# Altium schematic sheet Sheet1.SchDoc(Sheet1)
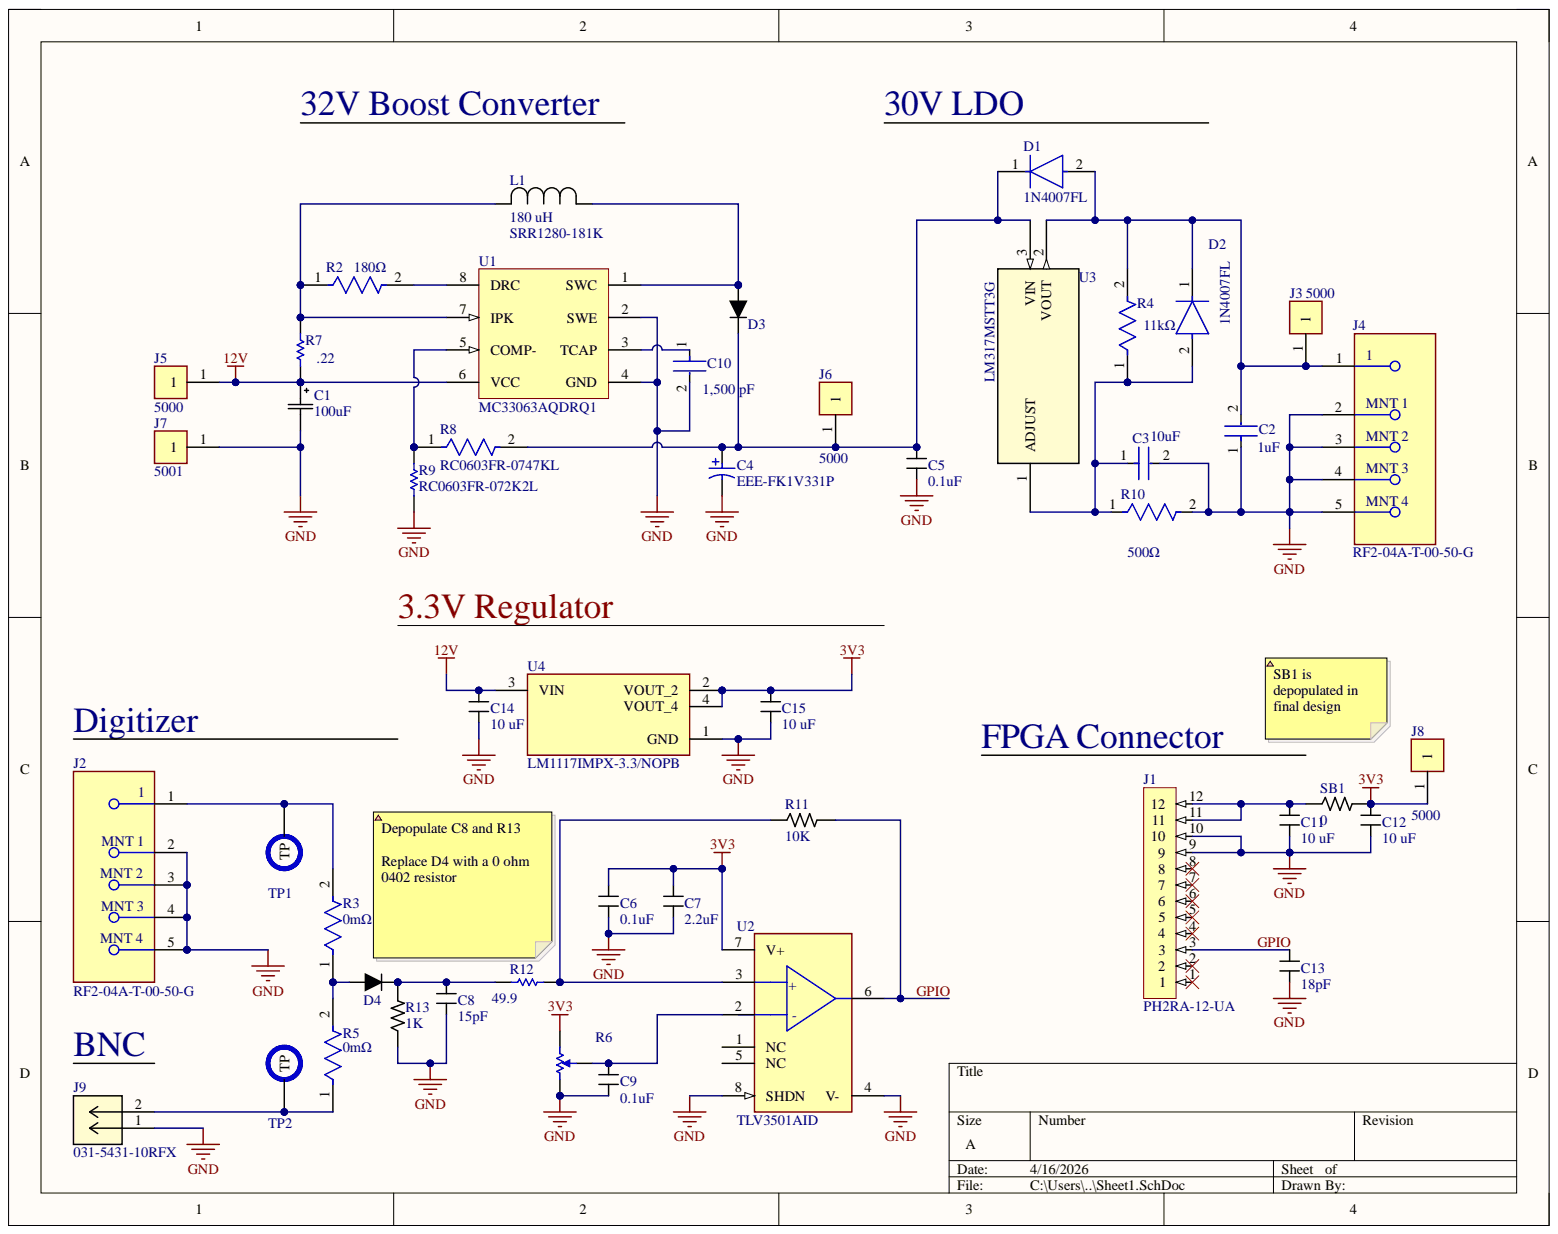
[diagram p0: Sheet1.SchDoc]

## Parts
C1  value 100uF  [PCAP_8x5.4-ELECT_NCA]  (hidden comment: 100uF electrolytic 35 V)
C2  Capacitance: 1uF  [FP-0603-L_1_6_0_1-W_0_8_0-IPC_A]  (hidden comment: UMK107BJ105KA-T)
C3  Capacitance: 10uF  [FP-GRM188-0_2-IPC_C]  (hidden comment: GRM188R6YA106MA73D)
C4  EEE-FK1V331P  [PNSC-EEE-FK1V331P_L]
C5  value 0.1uF  [CAPACITOR_0805]  (hidden comment: 100nF Ceramic 50V)
C6  value 0.1uF  [CAPACITOR_0603]  (hidden comment: 100nF Ceramic 25V)
C7  value 2.2uF  [CAPACITOR_0402]  (hidden comment: 2.2uF Ceramic 35V)
C8  value 15pF  [CAPACITOR_0402]  (hidden comment: 15pF Ceramic 50V)
C9  value 0.1uF  [CAPACITOR_0603]  (hidden comment: 100nF Ceramic 25V)
C10  value 1,500 pF  [FP-C0603C-CF-MFG]  (hidden comment: C0603C152F1GACTU)
C11  Value (uF): 10 uF  [CAPACITOR_0603]  (hidden comment: 10uF Ceramic 6.3V)
C12  Value (uF): 10 uF  [CAPACITOR_0603]  (hidden comment: 10uF Ceramic 6.3V)
C13  value 18pF  [CAPACITOR_0603]  (hidden comment: 18pF Ceramic 50V)
C14  Value (uF): 10 uF  [CAPACITOR_0603]  (hidden comment: 10uF Ceramic 6.3V)
C15  Value (uF): 10 uF  [CAPACITOR_0603]  (hidden comment: 10uF Ceramic 6.3V)
D1  1N4007FL  [FP-1N4007FL-MFG]
D2  1N4007FL  [FP-1N4007FL-MFG]
D3  STPS140Z  [SOD-123]  (value not drawn)
D4  BAR9002ELE6327XTMA1  [DIODE_0402]  (value not drawn)
J1  PH2RA-12-UA  [PH2RA-12-UA-Footprint-1]
J2  RF2-04A-T-00-50-G  [FP-RF2-04A-T-00-50-G-MFG]
J3  5000  [FP-5000-MFG]
J4  RF2-04A-T-00-50-G  [FP-RF2-04A-T-00-50-G-MFG]
J5  5000  [FP-5000-MFG]
J6  5000  [FP-5000-MFG]
J7  5001  [FP-5001-MFG]
J8  5000  [FP-5000-MFG]
J9  031-5431-10RFX  [031-5431-10RFX-Footprint-1]
L1  SRR1280-181K  value 180 uH  [SRR1280]
R2  value 180Ω  Resistance: 180Ω  [FP-CRGCQ0603-IPC_C]  (hidden comment: CRGCQ0603F180R)
R3  value 0mΩ  Resistance: 0mΩ  [FP-RMCF0603-MFG]  (hidden comment: RMCF0603ZT0R00)
R4  ERA-2AEB113X  [FP-ERA2A-MFG]  (value not drawn)
R5  value 0mΩ  Resistance: 0mΩ  [FP-RMCF0603-MFG]  (hidden comment: RMCF0603ZT0R00)
R6  TC33X-2-103E  [BOUR-TC33X-2_V]  (value not drawn)
R7  value .22  [RESC1608X55X30NL15T15]  (hidden comment: ERJ-3RQFR22V)
R8  RC0603FR-0747KL  [FP-RC0603FR-0747KL-MFG]
R9  RC0603FR-072K2L  [RESC1608X55X25LL10T15]
R10  Resistance: 500Ω  [RESC16080X45_RT0603-0_55_MFG]  (hidden comment: RT0603BRC07500RL)
R11  Value  (Ohms): 10K  [RESISTOR_0402]  (hidden comment: 10k Ohm 1% 1/16W)
R12  value 49.9  [RESC1005X40X25LL05T10]  (hidden comment: RC0402FR-0749R9L)
R13  Value  (Ohms): 1K  [RESISTOR_0603]  (hidden comment: 1k Ohm 5% 1/8W)
SB1  value 0  [RESISTOR_1206]  (hidden comment: JUMPER_0_1206)
TP1  PCB Test Point  [TESTPOINT_1.2MM]  (value not drawn)  (no BOM)
TP2  PCB Test Point  [TESTPOINT_1.2MM]  (value not drawn)  (no BOM)
U1  MC33063AQDRQ1  [D0008A_L]
U2  TLV3501AID  [FP-D0008A-IPC_C]
U3  LM317MSTT3G  [SOT223-3]
U4  LM1117IMPX-3.3/NOPB  [SOT-223-4]

## Nets
3V3: C6.1 C7.1 C12.1 C15.1 J8.1 R6.3 SB1.1 U2.7(V+) U4.2(VOUT_2) U4.4(VOUT_4)
12V: C1.1 C14.1 J5.1 R7.1 U1.6(VCC) U4.3(VIN)
GND: C1.2 C2.1 C3.2 C4.2 C5.2 C6.2 C7.2 C8.2 C9.2 C10.2 C11.2 C12.2 C13.2 C14.2 C15.2 J1.9 J1.10 J2.2 J2.3 J2.4 J2.5 J4.2 J4.3 J4.4 J4.5 J7.1 J9.1 R6.1 R9.1 R10.2 R13.2 U1.2(SWE) U1.4(GND) U2.4(V-) U2.8(SHDN) U4.1(GND)
GPIO: C13.1 J1.3 R11.1 U2.6
NetC2_2: C2.2 D1.2 D2.1 J3.1 J4.1 R4.2 U3.2
NetC3_1: C3.1 D2.2 R4.1 R10.1 U3.1
NetC4_1: C4.1 C5.1 D1.1 D3.2 J6.1 R8.2 U3.3
NetC8_1: C8.1 D4.2 R12.1 R13.1
NetC9_1: C9.1 R6.2 U2.2
NetC10_1: C10.1 U1.3(TCAP)
NetC11_1: C11.1 J1.11 J1.12 SB1.2
NetD3_1: D3.1 L1.1 U1.1(SWC)
NetD4_1: D4.1 R3.1 R5.2
NetJ2_1: J2.1 R3.2 TP1.TP
NetJ9_2: J9.2 R5.1 TP2.TP
NetL1_2: L1.2 R2.1 R7.2 U1.7(IPK)
NetR2_2: R2.2 U1.8(DRC)
NetR8_1: R8.1 R9.2 U1.5(COMP-)
NetR11_2: R11.2 R12.2 U2.3

Not connected: J1 1 2 4 5 6 7 8; U2 1(NC) 5(NC)

## Library data of the parts
C1 = CAP ALUM 100UF 20% 35V SMD: 100 µF 35 V Aluminum Electrolytic Capacitors Radial, Can - SMD 1000 Hrs; Manufacturer_Part_Number: UCB1V0R1MCL1GS; Mfr_Name: Nichicon; Made by: Tyson Nguyen; Type: DEV; Component Kind: Standard; Library Name: Capacitors.SchLib; RefDes: RefDes; Supplier 1: Mouser; Supplier Part Number 1: 667-EEE-FT1V101AP; Copyright: Copyright (C) 2022 Ultra Librarian. All rights reserved.
C2 = CMP-2000-05447-3: None; Dielectric: X5R; Tolerance: 10%; Voltage Rating: 50V; Case Code (Imperial): 0603; Case Code (Metric): 1608; Case/Package: 0603; Component Kind: Standard; Construction: Flat; Contact Plating: Nickel; Depth: 0.8mm; Halogen Free: Halogen Free; Height: 0.8mm; Length: 1.6mm; Library Name: Altium Content Vault; Max Operating Temperature: 85°C; Min Operating Temperature: -55°C; Mount: Surface Mount; Number of Pins: 2; Package Quantity: 1; Packaging: Tape and Reel; Radiation Hardening: No; RoHS Compliant: Yes; Series: M; Termination: SMD/SMT; Thickness: 0.035inch; Voltage Rating (DC): 50V; Width: 0.031inch
C3 = CMP-06035-045466-1: Chip Multilayer Ceramic Capacitors for General Purpose, 0603, 10uF, X5R, 15%, 20%, 35V; Case Code (Imperial): 0603; Case Code (Metric): 1608; Case/Package: 0603; Component Kind: Standard; Dielectric: X5R; Length: 0.063inch; Library Name: Altium Content Vault; Max Operating Temperature: 85°C; Min Operating Temperature: -55°C; Mount: Surface Mount; Packaging: Tape and Reel; Thickness: 0.039inch; Tolerance: 20%; Voltage Rating: 35V; Voltage Rating (DC): 35V; Width: 0.031inch
C4 = CMP-2000-06212-1: Aluminum Electrolytic Capacitor, 330 uF, 35 V, -55 to 105 degC, 2-Pin CAPAE, RoHS, Tape and Reel; Manufacturer: Panasonic; Capacitance: 330µF; Impedance: 0.08O; Lifetime@Temperature: 2000Hrs@105°C; Rated Voltage: 35V; Ripple Current: 850mA; Type: Can; Case Size/Dimension: Ø10x10.2mm; Component Kind: Standard; Datasheet URL: https://datasheet.ciiva.com/29313/443481-da-01-en-smd-elko-vfk-g-330-uf-35v-29313592.pdf; Datasheet Version: 07/2008; Library Name: Altium Content Vault; Manufacturer URL: http://www.panasonic.com/; Mounting Technology: SurfaceMount; Operating Temp Range: -55to+105°C; Operating Temp-Max: 105°C; Package Description: 2-Pin Capacitor Aluminum Electrolytic, Body 10.3 x 10.3 mm, Height 10.5 mm; Package Reference: EEE-FK1V331P; Series: FK
C5 = CAPACITOR_0.1uF_0805: Cap 100nF 50V +/-10%  Ceramic 0805; Made By: Robert Pafford; Component Kind: Standard; Library Name: Capacitors.SchLib; Supplier 1: Newark; Supplier Part Number 1: 38AH3645; Tolerance: +/- 10%; Voltage: 50V
C6 C9 = CAPACITOR_0.1uF_0603_25V: Cap 100nF 25V +/-10%  Ceramic 0603; Made By: Flip B; Voltage: 6.3V; Component Kind: Standard; ComponentLink1Description: Datsheet; ComponentLink1URL: https://www.mouser.com/datasheet/2/212/KEM_C1002_X7R_SMD-1102033.pdf; Library Name: Capacitors.SchLib; Supplier 1: Digikey; Supplier Part Number 1: 1276-1006-1-ND; Tolerance: +/- 10%
C7 = CAPACITOR_2.2uF_0402: Cap 2.2uF 35V +/-10%  Ceramic 0402; Made By: Flip B; Component Kind: Standard; Library Name: Capacitors.SchLib; Supplier 1: Digi-Key; Supplier Part Number 1: 445-9028-1-ND; Tolerance: +/- 10%; Voltage: 35V
C8 = CAPACITOR_0.000015uF_0402: CAP CER 15PF 50V C0G/NP0 0402; Made By: Robert Pafford; Tolerance: +/- 5%; Component Kind: Standard; Library Name: Capacitors.SchLib; Supplier 1: Newark; Supplier Part Number 1: 82AC9245; Voltage: 50V
C10 = CMP-1035-01965-2: CAP CER 1500PF 100V NP0 0603; Manufacturer: KEMET; Automotive Grade: Grade 1; Material: Ceramic; Temperature Characteristic: C0G; Temperature Coefficient: 30ppm/°C; Tolerance: 0.01; Automotive: Yes; Capacitance: 1,500 pF; Category: Cap; Component Kind: Standard; ComponentLink2Description: Datasheet; ComponentLink2URL: https://api.kemet.com/component-edge/download/datasheet/C0603C100J5GACAUTO.pdf; Device Class L1: Passive Components; Device Class L2: Capacitors; Device Class L3: Ceramic Capacitors; Digikey Description: CAP CER 1500PF 100V NP0 0603; Height: 0.87mm; Lead Free: Yes; Library Name: Altium Content Vault; Manufacturer Part Number: C0603C152F1GACTU; Mouser Description: Multilayer Ceramic Capacitors MLCC - SMD/SMT 100volts 1500pF 1% C0G; Octopart: https://octopart.com/c0603c152f1gactu-kemet-19049933; Package: C0603C-CF; RoHS: TRUE; Temperature: -55°C to +125°C; Temperature Range High: +125°C; Temperature Range Low: -55°C; Voltage Rating: 100 V
C11 C12 C14 C15 = CAPACITOR_10uF_0603: Cap 10uF 6.3V +/-20%  Ceramic 0603; Made By: Flip B; Component Kind: Standard; ComponentLink1Description: Digikey; ComponentLink1URL: https://www.digikey.com/en/products/detail/samsung-electro-mechanics/CL10A106MQ8NNNC/3886777; Library Name: Capacitors.SchLib; Supplier 1: Digi-Key; Supplier Part Number 1: 1276-1119-1-ND; Tolerance: +/- 20%; Voltage: 6.3V
C13 = CAPACITOR_0.000018uF_0603: Cap 18pF 50V +/-5%  Ceramic 0603; Made By: Flip B; Component Kind: Standard; ComponentLink1Description: Datsheet; ComponentLink1URL: https://www.mouser.com/datasheet/2/212/KEM_C1002_X7R_SMD-1102033.pdf; Library Name: Capacitors.SchLib; Supplier 1: Digi-Key; Supplier Part Number 1: 311-1061-1-ND; Tolerance: +/- 5%; Voltage: 50V
D1 D2 = CMP-10078-122641204-1: 1N4007FL Diode Gen Purp 1KV 1A SOD123FL; Capacitance: 6pF; Max Forward Surge Current (Ifsm): 30A; Max Junction Temperature (Tj): 150°C; Peak Reverse Current: 5µA; Component Kind: Standard; Forward Current: 1A; Height: 1.1mm; Library Name: Altium Content Vault; Max Operating Temperature: 150°C; Max Repetitive Reverse Voltage (Vrrm): 1kV; Min Operating Temperature: -55°C; NexarPartId: 122641204; Reverse Voltage: 1kV
D3 = STPS140Z: DIODE SCHOTTKY 40V 1A SOD123; Component Kind: Standard; Library Name: Diodes.SchLib; Supplier 1: DigiKey; Supplier Part Number 1: 497-7546-1-ND
D4 = RF Diode 80V 100mA: RF Diode PIN - Single 80V 100 mA 250 mW PG-TSLP-2-19; Forward Current: 100mA; Component Kind: Standard; Library Name: Diodes.SchLib; Package: 0402; Voltage - Peak Reverse: 80V
J1 = CMP-002-00071-1: Conn Unshrouded Header HDR 12 POS 2.54mm Solder RA Top Entry Thru-Hole Bag; Component Kind: Standard; Max Operating Temperature: 105°C; Min Operating Temperature: -40°C; Pins: 12; Library Name: XCOM_capstone
J2 J4 = CMP-42753-000002-1: CONN SMA JACK STR 50 OHM PCB; Component Kind: Standard; Library Name: Altium Content Vault
J3 J5 J6 J8 = CMP-002-00074-1: Cable Wrap, 1/2 Inch, Polyethylene, Natural, 50 Ft, Spirap Series; Component Kind: Standard; Mounting Technology: PCB, Through Hole; Library Name: XCOM_capstone
J7 = CMP-002-00076-1: Test Point, Mini, Thm, Pc, .300 L, .100 Od, Heat Resistant Nylon 46, Black; Component Kind: Standard; Mounting Technology: PCB, Through Hole; Pins: 1; Library Name: XCOM_capstone
J9 = CMP-002-00073-1: RF BNC Connector, Female, Panel Mount, Board And Panel Mount, Solder Terminal, Jack; Connector Type: Receptacle; Gender: Male; Voltage Rating: 500V; Component Kind: Standard; Max Operating Temperature: 85°C; Min Operating Temperature: -55°C; Mounting Technology: Bulkhead, PCB, Panel, Through Hole; Library Name: XCOM_capstone
L1 = SRR1280-181K: FIXED IND 180UH 1.75A 300 MOHM; Component Kind: Standard; Library Name: Inductors.SchLib; R: 300 mOhm; Supplier 1: DigiKey; Supplier Part Number 1: SRR1280-181KCT-ND
R2 = CMP-03211-005520-1: CRGCQ 0603 180R 1%; Manufacturer: TE Connectivity; Power Rating: 0.1W; Temperature Coefficient: ±100ppm/°C; Tolerance: 0.01; Voltage Rating: 75V; Ambient Temperature Range High: +70°C; Category: Res; Component Kind: Standard; ComponentLink2Description: Datasheet; ComponentLink2URL: https://www.te.com/commerce/DocumentDelivery/DDEController?Action=showdoc&DocId=Data+Sheet%7F1773204-3%7F1%7Fpdf%7FEnglish%7FENG_DS_1773204-3_1.pdf%7F4-2176339-520; Composition: Thick Film; Device Class L1: Passive Components; Device Class L2: Resistors; Device Class L3: Chip SMD Resistors; Digikey Description: CRGCQ 0603 180R 1%; Height: 0.55mm; Ipc Land Pattern Name: RESC16080X45; Lead Free: Yes; Library Name: Altium Content Vault; Manufacturer Part Number: CRGCQ0603F180R; Octopart: https://octopart.com/crgcq0603f180r-te+connectivity-91018047; Package: CRGCQ0603; RoHS: TRUE; Series: CRGCQ; Temperature: -55°C to +155°C; Temperature Range High: +155°C; Temperature Range Low: -55°C
R3 R5 = CMP-26527-000011-1: RES 0 OHM JUMPER 1/10W 0603; Manufacturer: Stackpole; Automotive Grade: Grade 0; Power Rating: 0.1W; Voltage Rating: 75V; Automotive: Yes; Category: Res; Component Kind: Standard; ComponentLink2Description: Datasheet; ComponentLink2URL: https://www.seielect.com/catalog/sei-rmcf_rmcp.pdf; Composition: Thick Film; Device Class L1: Passive Components; Device Class L2: Resistors; Device Class L3: Chip SMD Resistors; Digikey Description: RES 0 OHM JUMPER 1/10W 0603; Height: 0.55mm; Ipc Land Pattern Name: RESC15580X45; Lead Free: Yes; Library Name: Altium Content Vault; Manufacturer Part Number: RMCF0603ZT0R00; Octopart: https://octopart.com/rmcf0603zt0r00-stackpole+electronics-19213618; Package: RMCF0603; RoHS: TRUE; Series: RMCF; Temperature: -55°C to +155°C; Temperature Range High: +155°C; Temperature Range Low: -55°C
R4 = CMP-2002-05776-2: NexarPartId: 42798195; Max Power Dissipation: 63mW; Resistance: 11kΩ; Temperature Coefficient: 25ppm/°C; Tolerance: 0.1%; Voltage Rating: 25V; Case Code (Imperial): 0402; Case Code (Metric): 1005; Case/Package: 0402; Component Kind: Standard; Composition: Metal Film, Thin Film; Contact Plating: Tin; Depth: 500µm; Features: Automotive AEC-Q200; Height: 406.4µm; Lead Free: Lead Free; Length: 1mm; Library Name: Altium Content Vault; Lifecycle Status: Production; Max Operating Temperature: 155°C; Military Standard: Not; Min Operating Temperature: -55°C; Mount: Surface Mount; Number of Terminations: 2; Packaging: Cut Tape; Power Rating: 63mW; Radiation Hardening: No; REACH SVHC: No SVHC; RoHS: Compliant; Schedule B: 8533210045, 8533210045; Voltage Rating (DC): 25V; Width: 500µm
R6 = CMP-009-00060-2: Trimming Potentiometer, 10000 Ohm, -40 to 100 degC, 3-Pin SMD, RoHS, Tape and Reel; Manufacturer: Bourns; Power: 100mW; Resistance: 10k; Tolerance: 25%; Case/Package: TC33X-2; Component Kind: Standard; Composition: Potentiometer; Datasheet URL: http://www.bourns.com/docs/Product-Datasheets/TC33.pdf; Datasheet Version: Aug-16; Manufacturer URL: http://www.bourns.com/; Max Operating Temperature: 120°C; Min Operating Temperature: -40°C; Mounting Technology: SM; Package Description: 3-Pin Surface Mount Device, Body 3.8 x 3.6 mm; Package Quantity: 2500; Package Reference: TC33X-2; Packaging: TapeandReel; RoHS Compliant: True; Library Name: XCOM_capstone
R7 = CMP-2000-00853-1: Manufacturer: Panasonic; Component Kind: Standard; Library Name: Altium Content Vault; Part Number: ERJ-3RQFR22V
R8 = CMP-1659-00055-2: RES SMD 47K OHM 1% 1/10W 0603; Max Power Dissipation: 100mW; Resistance: 47kR; Temperature Coefficient: 100ppm/°C; Voltage Rating: 50V; Case Code (Imperial): 0603; Case Code (Metric): 1608; Case/Package: 0603; Component Kind: Standard; Construction: Rectangular; Depth: 0.8mm; Features: Moisture Resistant; Height: 0.022inch; Lead Free: Lead Free; Length: 0.063inch; Library Name: Altium Content Vault; Max Operating Temperature: 155°C; Military Standard: Not; Min Operating Temperature: -55°C; Number of Pins: 2; Number of Terminations: 2; Package Quantity: 1; Packaging: Tape and Reel; Power Rating: 100mW; Resistance Tolerance: 1%; Resistor Type: Thick Film; RoHS Compliant: Yes; Series: RC; Size Code: 0603; Technology: THICK FILM; Termination: SMD/SMT; Tolerance: 1%; Voltage Rating (DC): 50V; Width: 0.031inch
R9 = CMP-2000-06934-1: Thick Film Resistor, 2.2 KOhm, 100 mW, 50 V, +/- 1%, -55 to 155 degC, 2-Pin SMD (0603), RoHS, Tape and Reel; Manufacturer: Yageo; Power Rating: 100mW; Resistance: 2.2kOhms; Temperature Coefficient: 100PPM/C; Voltage Rating: 50V; Case Code - in: 0603; Case Code - mm: 1608; Component Kind: Standard; Datasheet URL: http://www.yageo.com/documents/recent/PYu-RC_Group_51_RoHS_L_7.pdf; Datasheet Version: Rev. 7, 05/2017; Library Name: Altium Content Vault; Manufacturer URL: http://www.yageo.com/; Mounting Technology: SurfaceMount; Operating Temperature Range: -55Cto+155C; Package Description: 2-Pin Surface Mount Device, Body 1.6 x 0.8 mm; Package Reference: 0603; Packaging: Reel; Product: ThickFilmResistorsGeneralPurpose; Series: RC; Termination Style: SMD/SMT; Tolerance: 1%
R10 = CMP-00621-87214386-1: Fixed Resistor, Thin Film, 0.1W, 500ohm, 75V, 0.1% +/-Tol, 15ppm/Cel, Surface Mount, 0603; Power Rating: 100mW; Temperature Coefficient: 15ppm/°C; Case/Package: 0603; Component Kind: Standard; ComponentLink1Description: Manufacturer URL; ComponentLink1URL: http://www.yageo.com/; ComponentLink2Description: Datasheet URL; ComponentLink2URL: https://www.yageo.com/upload/media/product/productsearch/datasheet/rchip/PYu-RT_1-to-0.01_RoHS_L_15.pdf; ComponentLink3Description: Package URL; ComponentLink3URL: http://www.yageo.com/exep/pages/download/literatures/PYu-R_Mount_10.pdf; Composition: Thin Film; Height: 550µm; Library Name: Altium Content Vault; Max Operating Temperature: 155°C; Min Operating Temperature: -55°C; Packaging: Tape & Reel; RoHS: Non-Compliant; Tolerance: 0.1%; Voltage Rating: 75V
R11 = RESISTOR_10K_0402: RES 10k OHM 1% 1/16W 0402; Made By: Robert Pafford; Tolerance: +/- 1%; Component Kind: Standard; Digikey Part Numer: CR0603-JW-104ELFTR-ND; Library Name: Resistors.SchLib; Supplier: Digikey; Supplier 1: Digi-Key; Supplier Part Number 1: YAG3436CT-ND; Wattage: 1/16W
R12 = CMP-2002-07709-1: Manufacturer: Yageo / Phycomp; Component Kind: Standard; Library Name: Altium Content Vault; Part Number: RC0402FR-0749R9L
R13 = RESISTOR_1K_0603: RES 1k OHM 5% 1/8W 0603; Made By: Robert Pafford; Tolerance: +/- 5%; Component Kind: Standard; ComponentLink1Description: Datasheet; ComponentLink1URL: https://www.bourns.com/docs/product-datasheets/CRxxxxx.pdf; Digikey Part Numer: CR0603-JW-104ELFTR-ND; Library Name: Resistors.SchLib; Supplier: Digikey; Supplier 1: Digi-Key; Supplier Part Number 1: 2019-RK73B1JTTD102JCT-ND; Wattage: 1/8W
SB1 = JUMPER_0_1206: JUMPER 0OHM 1206; Component Kind: Standard; Library Name: Resistors.SchLib
TP1 TP2 = TESTPOINT: Made By: Robert Pafford; Description: PCB Test Point; Library Name: Connectors.SchLib
U1 = CMP-0344-00012-2: Inverting Buck-Boost Regulator with 3 to 40 V Input and 1.25 to 40 V Output, -40 to 125 degC, 8-Pin SOIC (D), Green (RoHS & no Sb/Br); Manufacturer: Texas Instruments; Regulated Outputs(#): 1; SubFamily: Inverting Regulator; Switch Current Limit(Typ)(A): 1.5; Switching Frequency(Max)(kHz): 100; Vin(Max)(V): 40; Vin(Min)(V): 3; Vout(Min)(V): 1.25; Code_JEDEC: MS-012AA; Component Kind: Standard; ComponentLink1Description: Datasheet; ComponentLink1URL: http://www.ti.com/general/docs/lit/getliterature.tsp?genericPartNumber=MC33063A-Q1&fileType=pdf; ComponentLink2Description: Package Specification; ComponentLink2URL: http://www.ti.com/litv/pdf/msoi002j; DatasheetVersion: SLLS654B; Eco-Plan: Green (RoHS & no Sb/Br); Library Name: Altium Content Vault; Mounting Technology: Surface Mount; PackageDescription: 8-Pin Plastic Small Outline Integrated Circuit, 4.9 x 3.9 mm, Pitch 1.27 mm Pitch; PackageReference: D0008A; PackageVersion: revM, Jun-2011; PartNumber: MC33063AQDRQ1; Topology: Inverting Buck-Boost; Vout(Max)(V): 40
U2 = CMP-04896-000133-1: IC COMPARATOR 4.5NS R-R HS 8SOIC; Common Mode Rejection Ratio: 70dB; Hysteresis: 6mV; Input Bias Current: 10pA; Input Offset Voltage (Vos): 6.5mV; Max Supply Voltage: 5.5V; Min Supply Voltage: 2.7V; Nominal Supply Current: 3.2mA; Number of Channels: 1; Operating Supply Current: 5mA; Output Current: 74mA; Output Type: TTL; Power Supply Rejection Ratio (PSRR): 100dB; Propagation Delay: 10ns; Supply Type: Single; Case/Package: SOIC; Component Kind: Standard; Height: 1.58mm; Lead Free: Lead Free; Length: 4.9mm; Library Name: Altium Content Vault; Max Operating Temperature: 125°C; Min Operating Temperature: -40°C; Mount: Surface Mount; Number of Elements: 1; Number of Pins: 8; Package Quantity: 75; Packaging: Rail/Tube; Quiescent Current: 5mA; Radiation Hardening: No; REACH SVHC: No SVHC; Response Time: 4.5ns; RoHS Compliant: Yes; Type: General Purpose; Weight: 0.002677oz; Width: 3.91mm
U3 = LM317MSTT3G: Linear Voltage Regulator IC Positive Adjustable 1 Output 500mA SOT-223 (TO-261); Component Kind: Standard; Library Name: Integrated Circuits.SchLib; Supplier 1: DigiKey; Supplier Part Number 1: LM317MSTT3GOSCT-ND
U4 = LM1117IMPX-3.3/NOPB: 800-mA 15-V linear voltage regulator 4-SOT-223 -40 to 125; MF: Texas Instruments; Arrow_Electronics-Purchase-URL: https://snapeda.com/shop?store=Arrow+Electronics&id=410186; Availability: Good; Component Kind: Standard; DigiKey-Purchase-URL: https://snapeda.com/shop?store=DigiKey&id=410186; Library Name: Integrated Circuits.SchLib; Mouser-Purchase-URL: https://snapeda.com/shop?store=Mouser&id=410186; MP: LM1117IMPX-3.3/NOPB; Package: SOT-223-4 Texas Instruments; Price: None; SnapEDA_Link: https://www.snapeda.com/parts/LM1117IMPX-3.3/NOPB/Texas%20Instruments/view-part/410186/?ref=snap; Texas_Instruments-Purchase-URL: https://snapeda.com/shop?store=Texas+Instruments&id=410186
